# Altium schematic sheet Power.SchDoc
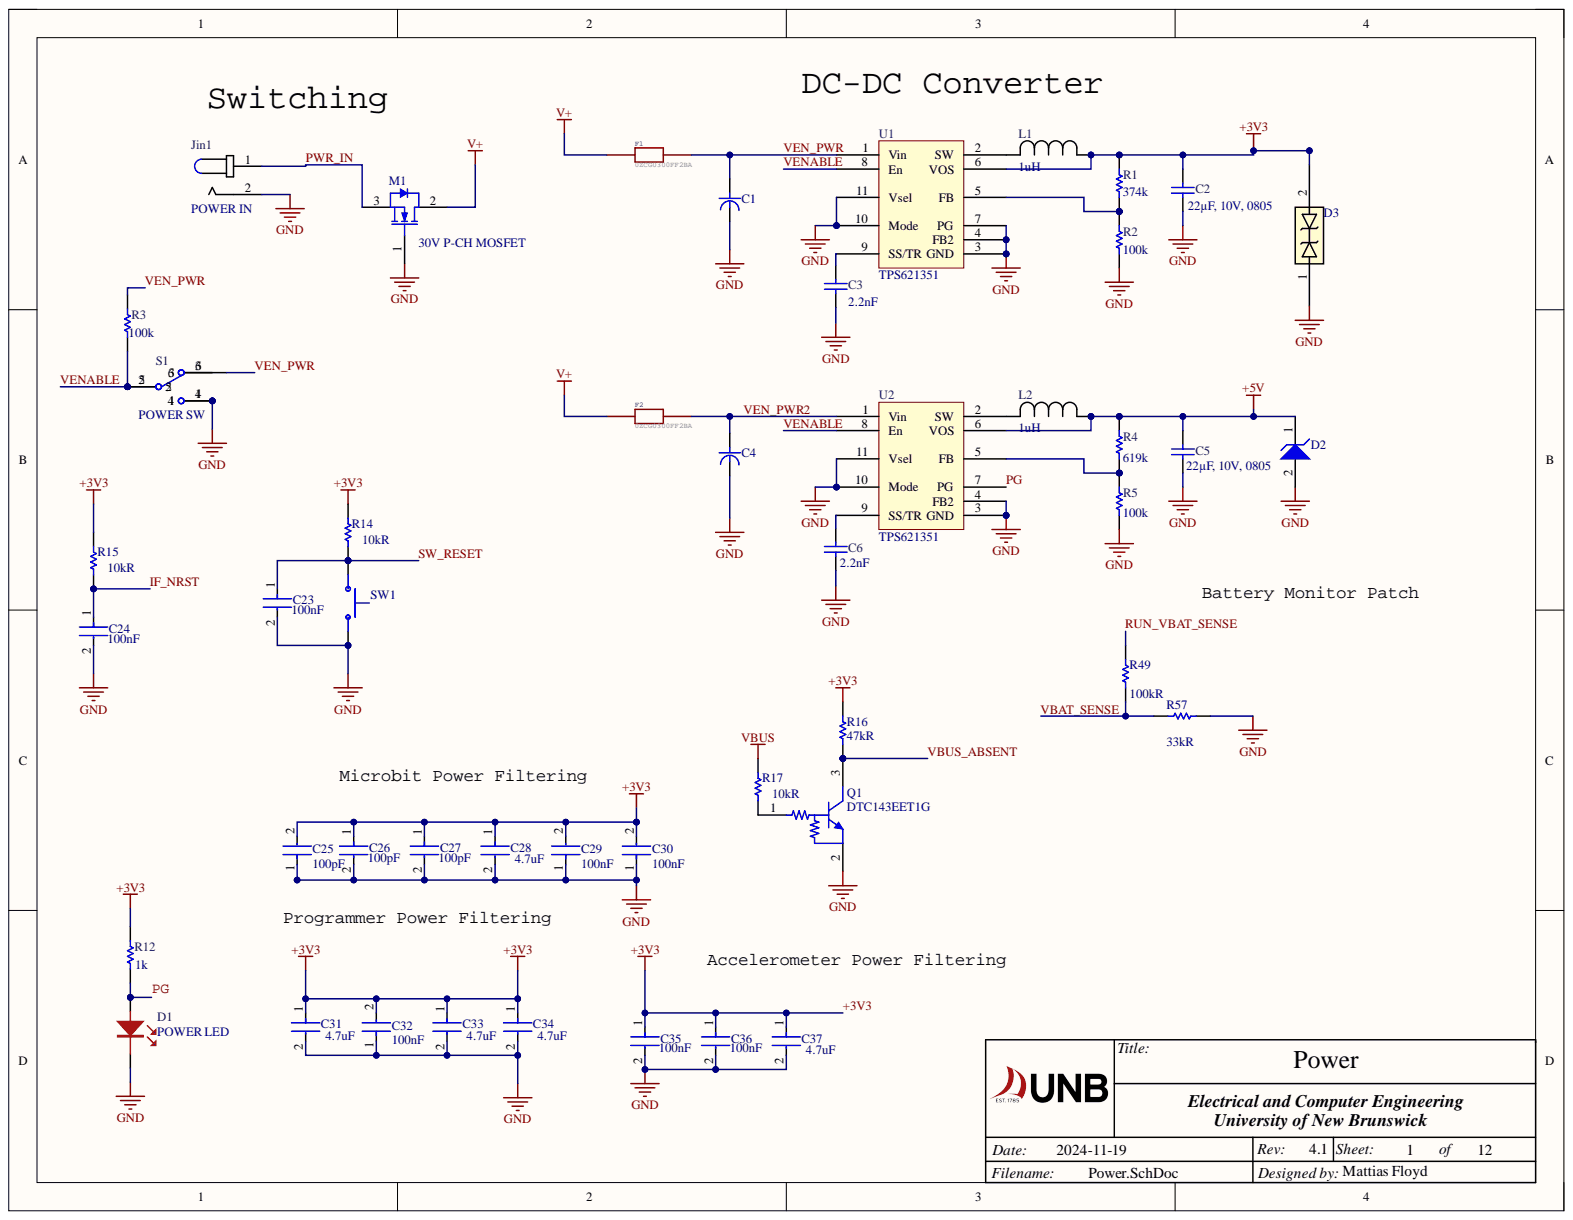
[diagram p0: Power.SchDoc]

## Parts
C1  CGA5L1X7R1V685K160AC  [CAPC3216X190N]  (value not drawn)
C2  22µF, 10V, 0805  [0805 Cap]
C3  2.2nF  [0603 Cap]
C4  CGA5L1X7R1V685K160AC  [CAPC3216X190N]  (value not drawn)
C5  22µF, 10V, 0805  [0805 Cap]
C6  2.2nF  [0603 Cap]
C23  Capacitance: 100nF  [0402 CAP]  (hidden comment: CAP GRM155R61H104KE14D)
C24  Capacitance: 100nF  [0402 CAP]  (hidden comment: CAP GRM155R61H104KE14D)
C25  Capacitance: 100pF  [0402 CAP]  (hidden comment: CAP CL05C101JB5NNNC)
C26  Capacitance: 100pF  [0402 CAP]  (hidden comment: CAP CL05C101JB5NNNC)
C27  Capacitance: 100pF  [0402 CAP]  (hidden comment: CAP CL05C101JB5NNNC)
C28  Capacitance: 4.7uF  [0402 CAP]  (hidden comment: CAP C1005X5R1A475K050BC)
C29  Capacitance: 100nF  [0402 CAP]  (hidden comment: CAP GRM155R61H104KE14D)
C30  Capacitance: 100nF  [0402 CAP]  (hidden comment: CAP GRM155R61H104KE14D)
C31  Capacitance: 4.7uF  [0402 CAP]  (hidden comment: CAP C1005X5R1A475K050BC)
C32  Capacitance: 100nF  [0402 CAP]  (hidden comment: CAP GRM155R61H104KE14D)
C33  Capacitance: 4.7uF  [0402 CAP]  (hidden comment: CAP C1005X5R1A475K050BC)
C34  Capacitance: 4.7uF  [0402 CAP]  (hidden comment: CAP C1005X5R1A475K050BC)
C35  Capacitance: 100nF  [0402 CAP]  (hidden comment: CAP GRM155R61H104KE14D)
C36  Capacitance: 100nF  [0402 CAP]  (hidden comment: CAP GRM155R61H104KE14D)
C37  Capacitance: 4.7uF  [0402 CAP]  (hidden comment: CAP C1005X5R1A475K050BC)
D1  POWER LED  [LED_0603_RED]
D2  MM3Z5V1ST1G  [ONSC-SOD-323-2-477-02_V]  (value not drawn)
D3  DIODE D5V0H1B2LP-7B  [X1-DFN1006-2_DIO-L]  (value not drawn)
F1  0ZCG0300FF2BA  [0ZCF]
F2  0ZCG0300FF2BA  [0ZCF]
Jin1  POWER IN  [CUI_PJ-063AH_PJ-063AH(Primary)]
L1  1uH  [ASPI-0530HI]
L2  1uH  [ASPI-0530HI]
M1  30V P-CH MOSFET  [INF-PG-SOT-323_L]
Q1  DTC143EET1G  [ONSC-SC-75-3-463-01_V]
R1  374k  [0603 Resistor]
R2  100k  [0603 Resistor]
R3  100k  [0603 Resistor]
R4  619k  [0603 Resistor]
R5  100k  [0603 Resistor]
R12  1k  [0603 Resistor]
R14  Resistance: 10kR  [0402 RES]  (hidden comment: RES MC00625W0402110K)
R15  Resistance: 10kR  [0402 RES]  (hidden comment: RES MC00625W0402110K)
R16  Resistance: 47kR  [0402 RES]  (hidden comment: RES RC0402JR-0747KL)
R17  Resistance: 10kR  [0402 RES]  (hidden comment: RES MC00625W0402110K)
R49  Resistance: 100kR  [0402 RES]  (hidden comment: RES MCWR04X1003FTL)
R57  Resistance: 33kR  [0402 RES]  (hidden comment: RES RC0402JR-0733KL)
S1  POWER SW  [EG1271A]
SW1  PTS636SK25FSMTRLFS  [PTS636 SK25F SMTR LFS]  (value not drawn)
U1  TPS621351  [VQFN]
U2  TPS621351  [VQFN]

## Nets
+5V: C5.2 D2.1 L2.1 R4.1 U2.6(VOS)
GND: C1.2 C2.1 C3.1 C4.2 C5.1 C6.1 C23.2 C24.2 C25.1 C26.2 C27.2 C28.2 C29.1 C30.1 C31.2 C32.1 C33.2 C34.2 C35.2 C36.2 C37.2 D1.1 D2.2 D3.1 Jin1.2 M1.1 Q1.2 R2.2 R5.2 R57.2 S1.1 S1.4 SW1.2 U1.3(GND) U1.4(FB2) U1.7(PG) U1.10(Mode) U1.11(Vsel) U2.3(GND) U2.4(FB2) U2.10(Mode) U2.11(Vsel)
V+: F1.P$1 F2.P$1 M1.2
VBUS: R17.2
+3V3: C2.2 C25.2 C26.1 C27.1 C28.1 C29.2 C30.2 C31.1 C32.2 C33.1 C34.1 C35.1 C36.1 C37.1 D3.2 L1.1 R1.1 R12.2 R14.2 R15.2 R16.2 U1.6(VOS)
IF_NRST: C24.1 R15.1
PG: D1.2 R12.1 U2.7(PG)
PWR_IN: Jin1.1 M1.3
RUN_VBAT_SENSE: R49.2
SW_RESET: C23.1 R14.1 SW1.1
VBAT_SENSE: R49.1 R57.1
VBUS_ABSENT: Q1.3 R16.1
VEN_PWR: C1.1 F1.P$2 R3.1 S1.6 U1.1(Vin)
VEN_PWR2: C4.1 F2.P$2 U2.1(Vin)
VENABLE: R3.2 S1.2 S1.5 U1.8(En) U2.8(En)
NetC3_2: C3.2 U1.9(SS/TR)
NetC6_2: C6.2 U2.9(SS/TR)
NetL1_2: L1.2 U1.2(SW)
NetL2_2: L2.2 U2.2(SW)
NetQ1_1: Q1.1 R17.1
NetR1_2: R1.2 R2.1 U1.5(FB)
NetR4_2: R4.2 R5.1 U2.5(FB)

Not connected: S1 3

## Library data of the parts
C1 C4 = CGA5L1X7R1V685K160AC: Ceramic Capacitor, Multilayer, Ceramic, 35V, 10% +Tol, 10% -Tol, X7R, 15% TC, 6.8uF, Surface Mount, 1206; Manufacturer: TDK; Multilayer: Yes; Capacitance: 6.8uF; Capacitor Type: Ceramic; Category: Ceramic Capacitors; Component Kind: Standard; ComponentLink1Description: https://datasheet.ciiva.com:443/pdfs/VipMasterIC/IC/TDKC/TDKC-S-A0008953915/TDKC-S-A0008953915-1.pdf?src-supplier=IHS+Markit; ComponentLink1URL: https://datasheet.ciiva.com:443/pdfs/VipMasterIC/IC/TDKC/TDKC-S-A0008953915/TDKC-S-A0008953915-1.pdf?src-supplier=IHS+Markit; ComponentLink2Description: http://product.tdk.com/capacitor/mlcc/detailed_information.php?lang=en&ref=jp&part_no=CGA5L1X7R1V685K160AC&src-supplier=Mouser; ComponentLink2URL: http://product.tdk.com/capacitor/mlcc/detailed_information.php?lang=en&ref=jp&part_no=CGA5L1X7R1V685K160AC&src-supplier=Mouser; ComponentLink3Description: https://product.tdk.com/info/en/catalog/datasheets/mlcc_automotive_general_en.pdf?src-supplier=Digikey; ComponentLink3URL: https://product.tdk.com/info/en/catalog/datasheets/mlcc_automotive_general_en.pdf?src-supplier=Digikey; ComponentLink4Description: https://product.tdk.com/info/en/catalog/spec/mlccspec_automotive_general_midvoltage_en.pdf?src-supplier=Digikey; ComponentLink4URL: https://product.tdk.com/info/en/catalog/spec/mlccspec_automotive_general_midvoltage_en.pdf?src-supplier=Digikey; ComponentLink5Description: http://product.tdk.com/capacitor/mlcc/class/print_detail_pdf.php?lang=en&part_no=CGA5L1X7R1V685K160AC&src-supplier=Avnet; ComponentLink5URL: http://product.tdk.com/capacitor/mlcc/class/print_detail_pdf.php?lang=en&part_no=CGA5L1X7R1V685K160AC&src-supplier=Avnet; ComponentLink6Description: https://datasheet.octopart.com/CGA5L1X7R1V685K160AC-TDK-datasheet-165583812.pdf?src-supplier=TME; ComponentLink6URL: https://datasheet.octopart.com/CGA5L1X7R1V685K160AC-TDK-datasheet-165583812.pdf?src-supplier=TME; Dielectric Material: Ceramic; Height: 1.6mm; JESD-609 Code: e3; Length: 3.2mm; Manufacturer Part Number: CGA5L1X7R1V685K160AC; Max Operating Temperature: 125°C; Min Operating Temperature: -55°C; Negative Tolerance: 10%; Number of Pins: 2; Package Style: SMTMeter; Packaging: Tape and Reel; Positive Tolerance: 10%; Pricing 1: 1=0.76, 10=0.531, 50=0.447, 100=0.364, 500=0.30334, 1000=0.25544 (USD); Rated DC Voltage (URdc): 35V; Reach SVHC Compliant: Yes; RoHS: Yes; RoHS Compliant: Yes; Size Code: 1206; Stock 1: 11896; Supplier 1: Digikey; Supplier Part Number 1: 445-181026-1-ND; Temperature Characteristics Code: X7R; Terminal Finish: Nickel; Width: 1.6mm; Library Name: bBoard for UNB Rev 4.SCHLIB
C2 C5 = Cap Semi: CAPACITOR, MLCC, X5R, 22UF, 10V, 0805; Product Range:C Series; Capacitance:22 F; Capacitance Tolerance: 20%; Dielectric Characteristic:X5R; Voltage Rating:10V; Ceramic Capacitor Case:0805 [2012 Metric]; Operating Temperature ;RoHS Compliant: Yes; Manufacturer: TDK; Manufacturer Part Number: C2012X5R1A226M085AC; Capacitance: 22uF; Case Code (Imperial): 0805; Case Code (Metric): 2012; Case/Package: 0805; Category: Ceramic Capacitors; Component Kind: Standard; ComponentLink1Description: http://www.avx.com/docs/Catalogs/cx7r.pdf; ComponentLink1URL: http://www.avx.com/docs/Catalogs/cx7r.pdf; ComponentLink2Description: https://datasheet.ciiva.com/24216/c2012x5r1a226m085ac-264883-24216482.pdf?src-supplier=Mouser; ComponentLink2URL: https://datasheet.ciiva.com/24216/c2012x5r1a226m085ac-264883-24216482.pdf?src-supplier=Mouser; ComponentLink3Description: https://datasheet.ciiva.com/26834/getdatasheetpartid-711053-26834411.pdf?src-supplier=Verical; ComponentLink3URL: https://datasheet.ciiva.com/26834/getdatasheetpartid-711053-26834411.pdf?src-supplier=Verical; ComponentLink4Description: https://datasheet.ciiva.com/6287/tdkmlcccaprange-6287141.pdf?src-supplier=Digi-Key; ComponentLink4URL: https://datasheet.ciiva.com/6287/tdkmlcccaprange-6287141.pdf?src-supplier=Digi-Key; ComponentLink5Description: https://datasheet.ciiva.com/16471/e412-c-16471846.pdf?src-supplier=Future+Electronics; ComponentLink5URL: https://datasheet.ciiva.com/16471/e412-c-16471846.pdf?src-supplier=Future+Electronics; ComponentLink6Description: https://datasheet.ciiva.com/6287/tdkmlcccaprange-6287144.pdf?src-supplier=Digi-Key; ComponentLink6URL: https://datasheet.ciiva.com/6287/tdkmlcccaprange-6287144.pdf?src-supplier=Digi-Key; ComponentLink7Description: https://datasheet.ciiva.com/6287/tdkmlcccaprange-6287147.pdf?src-supplier=Digi-Key; ComponentLink7URL: https://datasheet.ciiva.com/6287/tdkmlcccaprange-6287147.pdf?src-supplier=Digi-Key; Composition: Ceramic; Depth: 1.25mm; Dielectric: X5R; Dielectric Material: Ceramic; Height: 0.85mm; Lead Free: Lead Free; Length: 2mm; Library Name: CAN_Interface_Library.SCHLIB; Max Operating Temperature: 85°C; Min Operating Temperature: -55°C; Mount: Surface Mount; Package Quantity: 1; Packaging: Tape and Reel; Radiation Hardening: No; REACH SVHC: No SVHC; RoHS: Yes; Signal Integrity: Cap Semi; Simulation: CAP; Stock 1: 64294; Supplier 1: Digi-Key; Supplier Part Number 1: 445-7663-1-ND; Termination: SMD/SMT; Thickness: 0.037inch; Tolerance: 20%; Value: 22µF, 10V, 0805; Voltage Rating: 10V; Voltage Rating (DC): 10V; Weight: 0.000194oz; Width: 1.25mm
C3 C6 = Cap Semi: Cap Ceramic 0.0022uF 50VDC X7R 10% SMD 0603 Paper T/R; Manufacturer: Samsung; Manufacturer Part Number: CL10B222KB8NNNC; Voltage: 25V; Voltage Rating: 50V; Capacitance: 2.2nF; Case Code (Imperial): 0603; Case Code (Metric): 1608; Case/Package: 0603; Category: Ceramic Capacitors; Component Kind: Standard; ComponentLink1Description: http://www.avx.com/docs/Catalogs/cx7r.pdf; ComponentLink1URL: http://www.avx.com/docs/Catalogs/cx7r.pdf; ComponentLink2Description: https://datasheet.ciiva.com/15195/getdatasheetpartid-354051-15195736.pdf?src-supplier=Verical; ComponentLink2URL: https://datasheet.ciiva.com/15195/getdatasheetpartid-354051-15195736.pdf?src-supplier=Verical; ComponentLink3Description: https://datasheet.ciiva.com/29198/458008-da-01-en-vs-kondensator-0603-29198600.pdf?src-supplier=Conrad; ComponentLink3URL: https://datasheet.ciiva.com/29198/458008-da-01-en-vs-kondensator-0603-29198600.pdf?src-supplier=Conrad; ComponentLink4Description: https://datasheet.ciiva.com/26310/mlcc-catalogdownload2013-eng-26310312.pdf?src-supplier=Distrelec; ComponentLink4URL: https://datasheet.ciiva.com/26310/mlcc-catalogdownload2013-eng-26310312.pdf?src-supplier=Distrelec; Contact Plating: Tin; Depth: 0.8mm; Dielectric: X7R; Height: 0.8mm; Lead Free: Lead Free; Length: 1.6mm; Library Name: CAN_Interface_Library.SCHLIB; Material: Ceramic; Max Operating Temperature: 125°C; Min Operating Temperature: -55°C; Mount: Surface Mount; Package Quantity: 1; Packaging: Tape and Reel; Radiation Hardening: No; RoHS: Yes; Signal Integrity: Cap Semi; Simulation: CAP; Stock 1: 366327; Supplier 1: Digi-Key; Supplier Part Number 1: 1276-1110-1-ND; Thickness: 0.035inch; Tolerance: 10%; Value: 2.2nF; Voltage Rating (DC): 50V; Width: 0.031inch
C23 C24 C29 C30 C32 C35 C36 = CAP GRM155R61H104KE14D: Ceramic Capacitor, Multilayer, Ceramic, 50V, 10% +Tol, 10% -Tol, X5R, 15% TC, 0.1uF, Surface Mount, 0402; Manufacturer: Murata; Multilayer: Yes; Capacitor Type: Ceramic; Category: Ceramic Capacitors; Component Kind: Standard; ComponentLink1Description: https://datasheet.ciiva.com:443/pdfs/VipMasterIC/IC/MURE/MURE-S-A0004362469/MURE-S-A0004364758-1.pdf?src-supplier=IHS+Markit; ComponentLink1URL: https://datasheet.ciiva.com:443/pdfs/VipMasterIC/IC/MURE/MURE-S-A0004362469/MURE-S-A0004364758-1.pdf?src-supplier=IHS+Markit; ComponentLink2Description: http://www.mouser.com/ds/2/281/c02e-2905.pdf?src-supplier=Mouser; ComponentLink2URL: http://www.mouser.com/ds/2/281/c02e-2905.pdf?src-supplier=Mouser; ComponentLink3Description: http://www.farnell.com/datasheets/2079150.pdf?src-supplier=Farnell; ComponentLink3URL: http://www.farnell.com/datasheets/2079150.pdf?src-supplier=Farnell; ComponentLink4Description: http://www.farnell.com/datasheets/2079150.pdf?src-supplier=Element14; ComponentLink4URL: http://www.farnell.com/datasheets/2079150.pdf?src-supplier=Element14; ComponentLink5Description: https://datasheet.octopart.com/GRM155R61H104KE14D-Murata-datasheet-66077066.pdf?src-supplier=Element14; ComponentLink5URL: https://datasheet.octopart.com/GRM155R61H104KE14D-Murata-datasheet-66077066.pdf?src-supplier=Element14; ComponentLink6Description: http://search.murata.co.jp/Ceramy/image/img/A01X/G101/ENG/GRM155R61H104KE14-01.pdf?src-supplier=Digikey; ComponentLink6URL: http://search.murata.co.jp/Ceramy/image/img/A01X/G101/ENG/GRM155R61H104KE14-01.pdf?src-supplier=Digikey; ComponentLink7Description: https://datasheet.octopart.com/GRM155R61H104KE14D-Murata-datasheet-22122223.pdf?src-supplier=Future+Electronics; ComponentLink7URL: https://datasheet.octopart.com/GRM155R61H104KE14D-Murata-datasheet-22122223.pdf?src-supplier=Future+Electronics; ComponentLink8Description: https://datasheet.octopart.com/GRM155R61H104KE14D-Murata-datasheet-149523029.pdf?src-supplier=Newark; ComponentLink8URL: https://datasheet.octopart.com/GRM155R61H104KE14D-Murata-datasheet-149523029.pdf?src-supplier=Newark; Dielectric Material: Ceramic; Height: 0.5mm; JESD-609 Code: e3; Length: 1mm; Manufacturer Part Number: GRM155R61H104KE14D; Max Operating Temperature: 85°C; Min Operating Temperature: -55°C; Mount: Surface Mount; Negative Tolerance: 10%; Number of Pins: 2; Package Shape: Rectangular; Package Style: SMTMeter; Packaging: Tape and Reel; Positive Tolerance: 10%; Pricing 1: 1=0.1, 10=0.031, 50=0.018, 100=0.0139, 500=0.01046, 1000=0.00821, 2500=0.00747, 5000=0.00687 (USD); Rated DC Voltage (URdc): 50V; Reach SVHC Compliant: Yes; RoHS: Yes; RoHS Compliant: Yes; Size Code: 0402; Stock 1: 1615754; Supplier 1: Digikey; Temperature Characteristics Code: X5R; Terminal Finish: Nickel; Termination: Wraparound; Width: 0.5mm; Library Name: bBoard for UNB Rev 3.SCHLIB
C25-C27 = CAP CL05C101JB5NNNC: Ceramic Capacitor, Multilayer, Ceramic, 50V, 5% +Tol, 5% -Tol, C0G, -/+30ppm/Cel TC, 0.0001uF, Surface Mount, 0402; Manufacturer: Samsung; Multilayer: Yes; Capacitor Type: Ceramic; Category: Ceramic Capacitors; Component Kind: Standard; ComponentLink1Description: https://datasheet.ciiva.com:443/pdfs/VipMasterIC/IC/SEMS/SEMS-S-A0008529205/SEMS-S-A0008529205-1.pdf?src-supplier=IHS+Markit; ComponentLink1URL: https://datasheet.ciiva.com:443/pdfs/VipMasterIC/IC/SEMS/SEMS-S-A0008529205/SEMS-S-A0008529205-1.pdf?src-supplier=IHS+Markit; ComponentLink2Description: https://datasheet.ciiva.com/15195/getdatasheetpartid-357528-15195665.pdf?src-supplier=Verical; ComponentLink2URL: https://datasheet.ciiva.com/15195/getdatasheetpartid-357528-15195665.pdf?src-supplier=Verical; ComponentLink3Description: https://datasheet.ciiva.com/29198/458492-da-01-en-vs-kondensator-0402-29198529.pdf?src-supplier=Conrad; ComponentLink3URL: https://datasheet.ciiva.com/29198/458492-da-01-en-vs-kondensator-0402-29198529.pdf?src-supplier=Conrad; ComponentLink4Description: https://datasheet.ciiva.com/16379/cl05b104kq5nnnc-16379226.pdf?src-supplier=Future+Electronics; ComponentLink4URL: https://datasheet.ciiva.com/16379/cl05b104kq5nnnc-16379226.pdf?src-supplier=Future+Electronics; Dielectric Material: Ceramic; Height: 0.55mm; JESD-609 Code: e3; Length: 1mm; Manufacturer Part Number: CL05C101JB5NNNC; Max Operating Temperature: 125°C; Min Operating Temperature: -55°C; Mount: Surface Mount; Negative Tolerance: 5%; Number of Pins: 2; Package Shape: Rectangular; Package Style: SMTMeter; Packaging: Tape and Reel; Positive Tolerance: 5%; Pricing 1: 1=0.1, 10=0.017, 50=0.01, 100=0.0077, 500=0.00584, 1000=0.00458, 2500=0.00417, 5000=0.00383 (USD); Rated DC Voltage (URdc): 50V; Reach SVHC Compliant: Yes; RoHS: Yes; RoHS Compliant: Yes; Size Code: 0402; Stock 1: 4909001; Supplier 1: Digikey; Supplier Part Number 1: 1276-1025-6-ND; Temperature Characteristics Code: C0G; Terminal Finish: Nickel; Termination: Wraparound; Width: 0.5mm; Library Name: bBoard for UNB Rev 3.SCHLIB
C28 C31 C33 C34 C37 = CAP C1005X5R1A475K050BC: Ceramic Capacitor, Multilayer, Ceramic, 10V, 10% +Tol, 10% -Tol, X5R, 15% TC, 4.7uF, Surface Mount, 0402; Manufacturer: TDK; Multilayer: Yes; Capacitor Type: Ceramic; Category: Ceramic Capacitors; Component Kind: Standard; ComponentLink1Description: https://datasheet.ciiva.com:443/pdfs/VipMasterIC/IC/TDKC/TDKC-S-A0008796792/TDKC-S-A0008796792-1.pdf?src-supplier=IHS+Markit; ComponentLink1URL: https://datasheet.ciiva.com:443/pdfs/VipMasterIC/IC/TDKC/TDKC-S-A0008796792/TDKC-S-A0008796792-1.pdf?src-supplier=IHS+Markit; ComponentLink2Description: https://datasheet.ciiva.com/14895/tdkmlcccaprange-14895670.pdf?src-supplier=Digikey; ComponentLink2URL: https://datasheet.ciiva.com/14895/tdkmlcccaprange-14895670.pdf?src-supplier=Digikey; ComponentLink3Description: https://datasheet.ciiva.com/14895/tdkmlcccaprange-14895671.pdf?src-supplier=Digikey; ComponentLink3URL: https://datasheet.ciiva.com/14895/tdkmlcccaprange-14895671.pdf?src-supplier=Digikey; ComponentLink4Description: https://datasheet.ciiva.com/14895/tdkmlcccaprange-14895672.pdf?src-supplier=Digikey; ComponentLink4URL: https://datasheet.ciiva.com/14895/tdkmlcccaprange-14895672.pdf?src-supplier=Digikey; Dielectric Material: Ceramic; Height: 0.5mm; JESD-609 Code: e3; Length: 1mm; Manufacturer Part Number: C1005X5R1A475K050BC; Max Operating Temperature: 85°C; Min Operating Temperature: -55°C; Mount: Surface Mount; Negative Tolerance: 10%; Number of Pins: 2; Package Shape: Rectangular; Package Style: SMTMeter; Packaging: Tape and Reel; Positive Tolerance: 10%; Pricing 1: 1=0.28, 10=0.183, 50=0.1282, 100=0.1035, 500=0.07688, 1000=0.06727, 2500=0.06406, 5000=0.05926 (USD); Rated DC Voltage (URdc): 10V; Reach SVHC Compliant: Yes; RoHS: Yes; RoHS Compliant: Yes; Size Code: 0402; Stock 1: 1776806; Supplier 1: Digikey; Supplier Part Number 1: 445-13820-6-ND; Temperature Characteristics Code: X5R; Terminal Finish: Nickel; Termination: Wraparound; Width: 0.5mm; Library Name: bBoard for UNB Rev 4.SCHLIB
D1 = LED_0603_RED: LED, Red, 630 nm, 1.9 V, 30 mA, 60 mcd; Manufacturer: Wurth Electronics; Manufacturer Part Number: 150060SS75000; Dominant Wavelength: 630nm; Forward Current: 30mA; Forward Voltage: 1.9V; Lens Style: Clear,Rectangular; Luminous Intensity: 60mcd; Test Current: 20mA; Viewing Angle: 140°; Case Code (Imperial): 0603; Case Code (Metric): 1608; Case/Package: 0603; Category: LEDs; Color: Red; Component Kind: Standard; ComponentLink1Description: https://datasheet.ciiva.com/23843/150060ss75000-23843248.pdf?src-supplier=Newark; ComponentLink1URL: https://datasheet.ciiva.com/23843/150060ss75000-23843248.pdf?src-supplier=Newark; ComponentLink2Description: https://datasheet.ciiva.com/29279/759429-da-01-en-chipled-0603-w-kl-s-rot-150060ss75000-29279208.pdf?src-supplier=Conrad; ComponentLink2URL: https://datasheet.ciiva.com/29279/759429-da-01-en-chipled-0603-w-kl-s-rot-150060ss75000-29279208.pdf?src-supplier=Conrad; ComponentLink3Description: https://datasheet.ciiva.com/48430/150060ss75000-eng-tds-48430042.pdf?src-supplier=Distrelec; ComponentLink3URL: https://datasheet.ciiva.com/48430/150060ss75000-eng-tds-48430042.pdf?src-supplier=Distrelec; Height: 0.7mm; Length: 1.6mm; Max Operating Temperature: 85°C; Min Operating Temperature: -40°C; Mount: Surface Mount; Package Quantity: 4000; Packaging: Tape and Reel; REACH SVHC: Unknown; RoHS: Yes; Stock 1: 15937; Supplier 1: Digi-Key; Supplier Part Number 1: 732-4979-1-ND; Wavelength: 630nm; Width: 0.8mm; Library Name: bBoard for UNB Rev 4.SCHLIB
D2 = CMP-1060-00694-1: Zener Voltage Regulator, 300 mW, Tight Tolerance Portfolio, 2-Pin SOD-323, Pb-Free, Tape and Reel; Manufacturer: ON Semiconductor; Element Configuration: Single; ESD Protection: Yes; Forward Voltage: 900mV; Impedance: 60R; Material: Plastic; Max Power Dissipation: 200mW; Max Reverse Leakage Current: 2uA; Test Current: 5mA; Tolerance: 2%; Voltage Rating (DC): 5.1V; Zener Current: 10mA; Zener Voltage: 5.09V; Case/Package: SOD-323-2; Category: Zener Diodes; Component Kind: Standard; ComponentLink1Description: Manufacturer URL; ComponentLink1URL: http://www.onsemi.com/; ComponentLink2Description: Datasheet; ComponentLink2URL: http://www.onsemi.cn/pub_link/Collateral/MM3Z2V4ST1-D.PDF; ComponentLink3Description: Package Specification; ComponentLink3URL: http://www.onsemi.com/pub_link/Collateral/477-02.PDF.1; ComponentLink4Description: https://datasheet.ciiva.com/10630/mm3z2v4st1-d-10630224.pdf?src-supplier=Avnet; ComponentLink4URL: https://datasheet.ciiva.com/10630/mm3z2v4st1-d-10630224.pdf?src-supplier=Avnet; ComponentLink5Description: https://datasheet.ciiva.com/894/mm3z2v4st1-d-894646.pdf?src-supplier=Digi-Key; ComponentLink5URL: https://datasheet.ciiva.com/894/mm3z2v4st1-d-894646.pdf?src-supplier=Digi-Key; ComponentLink6Description: https://datasheet.ciiva.com/25928/mm3z2v4st1-d-25928233.pdf?src-supplier=Avnet+Europe; ComponentLink6URL: https://datasheet.ciiva.com/25928/mm3z2v4st1-d-25928233.pdf?src-supplier=Avnet+Europe; ComponentLink7Description: https://datasheet.ciiva.com/22626/1878251-22626909.pdf?src-supplier=Element14; ComponentLink7URL: https://datasheet.ciiva.com/22626/1878251-22626909.pdf?src-supplier=Element14; ComponentLink8Description: https://datasheet.ciiva.com/894/mm3z2v4st1-d-894647.pdf?src-supplier=Digi-Key; ComponentLink8URL: https://datasheet.ciiva.com/894/mm3z2v4st1-d-894647.pdf?src-supplier=Digi-Key; ComponentLink9Description: https://datasheet.ciiva.com/894/mm3z2v4st1-d-894648.pdf?src-supplier=Digi-Key; ComponentLink9URL: https://datasheet.ciiva.com/894/mm3z2v4st1-d-894648.pdf?src-supplier=Digi-Key; ComponentLink10Description: https://datasheet.ciiva.com/16397/mm3z2v4st1-d-16397690.pdf?src-supplier=Future+Electronics; ComponentLink10URL: https://datasheet.ciiva.com/16397/mm3z2v4st1-d-16397690.pdf?src-supplier=Future+Electronics; ComponentLink11Description: https://datasheet.ciiva.com/22626/1878251-22626906.pdf?src-supplier=Element14; ComponentLink11URL: https://datasheet.ciiva.com/22626/1878251-22626906.pdf?src-supplier=Element14; ComponentLink12Description: https://datasheet.ciiva.com/16473/mm3z2v4st1-d-16473517.pdf?src-supplier=Avnet+Asia; ComponentLink12URL: https://datasheet.ciiva.com/16473/mm3z2v4st1-d-16473517.pdf?src-supplier=Avnet+Asia; Contact Plating: Tin,Matte; Height: 1mm; Lead Free: Lead Free; Length: 1.8mm; Library Name: Altium Content Vault; Manufacturer Part Number: MM3Z5V1ST1G; Max Operating Temperature: 150°C; Min Operating Temperature: -65°C; Number of Pins: 2; Package Quantity: 3000; Packaging: Tape and Reel; Peak Reverse Current: 2uA; Power Dissipation: 200mW; Power Rating: 200mW; Radiation Hardening: No; REACH SVHC: No SVHC; RoHS: Yes; Stock 1: 204185; Supplier 1: Digi-Key; Supplier Part Number 1: MM3Z5V1ST1GOSCT-ND; Voltage Tolerance: 2%; Width: 1.35mm; Working Voltage: 5.1V
D3 = DIODE D5V0H1B2LP-7B: Trans Voltage Suppressor Diode, 375W, 5.5V V(RWM), Bidirectional, 1 Element, Silicon; Manufacturer: Diodes; Max Breakdown Voltage: 9V; Max Power Dissipation: 250mW; Min Breakdown Voltage: 6V; Number of Elements: 1; Package Body Material: Plastic; Reference Standard: IEC-61000-4-5; Category: TVS Diodes; Component Kind: Standard; ComponentLink1Description: https://datasheet.ciiva.com:443/pdfs/VipMasterIC/IC/DIOD/DIOD-S-A0009689747/DIOD-S-A0009691837-1.pdf?src-supplier=IHS+Markit; ComponentLink1URL: https://datasheet.ciiva.com:443/pdfs/VipMasterIC/IC/DIOD/DIOD-S-A0009689747/DIOD-S-A0009691837-1.pdf?src-supplier=IHS+Markit; ComponentLink2Description: https://datasheet.octopart.com/D5V0H1B2LP-7B-Diodes-Inc.-datasheet-144967973.pdf?src-supplier=Farnell; ComponentLink2URL: https://datasheet.octopart.com/D5V0H1B2LP-7B-Diodes-Inc.-datasheet-144967973.pdf?src-supplier=Farnell; ComponentLink3Description: https://www.diodes.com/assets/Datasheets/D5V0H1B2LP.pdf?src-supplier=Digikey; ComponentLink3URL: https://www.diodes.com/assets/Datasheets/D5V0H1B2LP.pdf?src-supplier=Digikey; ComponentLink4Description: https://datasheet.octopart.com/D5V0H1B2LP-7B-Diodes-Inc.-datasheet-144967973.pdf?src-supplier=Newark; ComponentLink4URL: https://datasheet.octopart.com/D5V0H1B2LP-7B-Diodes-Inc.-datasheet-144967973.pdf?src-supplier=Newark; Diode Element Material: SILICON; Diode Type: TRANS VOLTAGE SUPPRESSOR; Manufacturer Part Number: D5V0H1B2LP-7B; Max Operating Temperature: 150°C; Min Operating Temperature: -65°C; Non-rep Peak Rev Power Dis-Max: 375W; Number of Pins: 2; Package Shape: Rectangular; Package Style: CHIP CARRIERMeter; Polarity: Bidirectional; Pricing 1: 1=0.24, 10=0.164, 100=0.08, 500=0.06672, 1000=0.04636, 2000=0.04018, 5000=0.03727 (USD); Reach SVHC Compliant: Yes; Rep Pk Reverse Voltage-Max: 5.5V; RoHS: Yes; RoHS Compliant: Yes; Stock 1: 206000; Supplier 1: Digikey; Supplier Part Number 1: D5V0H1B2LP-7BDICT-ND; Surface Mount: Yes; Technology: AVALANCHE; Terminal Form: No Lead; Terminal Position: BOTTOM; Library Name: bBoard for UNB Rev 4.SCHLIB
F1 F2 = 0ZCG0300FF2BA: PTC RESET FUSE 24V 2A 2920; Manufacturer: Bel; Manufacturer Part Number: 0ZCF0200AF2C; Max Current Rating: 40A; Time to Trip: 5s; Trip Current: 4A; Case Code (Imperial): 2920; Case Code (Metric): 7351; Category: PTC Resettable Fuses; Component Kind: Standard; ComponentLink1Description: https://datasheet.ciiva.com/18550/0zcf-18550655.pdf?src-supplier=Digi-Key; ComponentLink1URL: https://datasheet.ciiva.com/18550/0zcf-18550655.pdf?src-supplier=Digi-Key; Hold Current: 2A; Lead Free: Lead Free; Package Quantity: 1; Packaging: Cut Tape; RoHS: Yes; Stock 1: 32175; Supplier 1: Digi-Key; Supplier Part Number 1: 507-1749-1-ND; Library Name: BrilliantLabsEagleLibrary.IntLib
Jin1 = PJ-063AH: CONN PWR JACK 2X5.5MM SOLDER; Manufacturer: CUI; Current Rating: 8A; Gender: Male; Insulation Resistance: 100MR; Number of Conductors: 2; Shielding: Shielded; Voltage Rating: 24V; Category: Connectors; Component Kind: Standard; ComponentLink1Description: http://www.cui.com/Product/Resource/FuturePDF/PJ-063AH.pdf?src-supplier=Future+Electronics; ComponentLink1URL: http://www.cui.com/Product/Resource/FuturePDF/PJ-063AH.pdf?src-supplier=Future+Electronics; ComponentLink2Description: https://www.cui.com/product/resource/digikeypdf/pj-063ah.pdf?src-supplier=Digi-Key; ComponentLink2URL: https://www.cui.com/product/resource/digikeypdf/pj-063ah.pdf?src-supplier=Digi-Key; ComponentLink3Description: https://www.cui.com/product/resource/digikeypdf/pj-063ah.pdf?src-supplier=Verical; ComponentLink3URL: https://www.cui.com/product/resource/digikeypdf/pj-063ah.pdf?src-supplier=Verical; ComponentLink4Description: https://www.cui.com/product/resource/digikeypdf/pj-063ah.pdf?src-supplier=Mouser; ComponentLink4URL: https://www.cui.com/product/resource/digikeypdf/pj-063ah.pdf?src-supplier=Mouser; ComponentLink5Description: https://www.cui.com/product/resource/digikeypdf/pj-063ah.pdf?src-supplier=Onlinecomponents.com; ComponentLink5URL: https://www.cui.com/product/resource/digikeypdf/pj-063ah.pdf?src-supplier=Onlinecomponents.com; ComponentLink6Description: https://www.cui.com/product/resource/digikeypdf/pj-063ah.pdf?src-supplier=Arrow+Electronics; ComponentLink6URL: https://www.cui.com/product/resource/digikeypdf/pj-063ah.pdf?src-supplier=Arrow+Electronics; ComponentLink7Description: https://www.cui.com/product/resource/digikeypdf/pj-063ah.pdf?src-supplier=NAC+Semi; ComponentLink7URL: https://www.cui.com/product/resource/digikeypdf/pj-063ah.pdf?src-supplier=NAC+Semi; ComponentLink8Description: https://www.cui.com/product/resource/digikeypdf/pj-063ah.pdf?src-supplier=Quest; ComponentLink8URL: https://www.cui.com/product/resource/digikeypdf/pj-063ah.pdf?src-supplier=Quest; Connector Type: Jack; Contact Gender: Male; Contact Material: Copper; Contact Plating: Nickel; Flammability Rating: UL94 V-0; Housing Color: Black; Housing Material: Thermoplastic; Lead Free: Lead Free; Manufacturer Part Number: PJ-063AH; Max Operating Temperature: 85°C; Min Operating Temperature: -25°C; Mount: Through Hole; Orientation: Horizontal; Package Quantity: 500; RoHS: Yes; Stock 1: 7747; Supplier 1: Digi-Key; Supplier Part Number 1: CP-063AH-ND; Termination: Through Hole; Library Name: BrilliantLabs_SchLib.SchLib
L1 L2 = Inductor: Fixed Inductors 1uH 20% -55C +125C; Manufacturer: Abracon; Manufacturer Part Number: ASPI-0530HI-1R0M-T2; Core Material: Carbonyl Powder; Current Rating: 7A; DC Resistance (DCR): 14mR; Max DC Current: 11A; Shielding: Unshielded; Test Frequency: 100kHz; Case/Package: Nonstandard; Category: Fixed Inductors; Component Kind: Standard; ComponentLink1Description: https://datasheet.ciiva.com/6691/aspi-0530hi-6691054.pdf?src-supplier=Digi-Key; ComponentLink1URL: https://datasheet.ciiva.com/6691/aspi-0530hi-6691054.pdf?src-supplier=Digi-Key; ComponentLink2Description: https://datasheet.ciiva.com/6691/aspi-0530hi-6691055.pdf?src-supplier=Digi-Key; ComponentLink2URL: https://datasheet.ciiva.com/6691/aspi-0530hi-6691055.pdf?src-supplier=Digi-Key; Composition: Wirewound; Height: 0.118inch; Height - Seated (Max): 0.118inch; Inductance: 1uH; Length: 0.22inch; Max Operating Temperature: 125°C; Min Operating Temperature: -55°C; Mount: Surface Mount; Package Quantity: 2000; Packaging: Tape and Reel; RoHS: Yes; Saturation Current: 11A; Stock 1: 21020; Supplier 1: Digi-Key; Supplier Part Number 1: 535-13037-1-ND; Termination: SMD/SMT; Tolerance: 20%; Value: 1uH; Width: 0.205inch; Library Name: bBoard for UNB Rev 4.SCHLIB
M1 = SI1317DL-T1-GE3: Small Signal Field-Effect Transistor, 1.4A I(D), 20V, 1-Element, P-Channel, Silicon, Metal-oxide Semiconductor FET; Manufacturer: Vishay Siliconix; Drain-source On Resistance-Max: 150mR; Number of Elements: 1; Operating Mode: ENHANCEMENT MODE; Package Body Material: Plastic; Power Dissipation-Max (Abs): 500mW; Transistor Application: SWITCHING; Category: MOSFETs; Component Kind: Standard; ComponentLink1Description: https://datasheet.ciiva.com:443/pdfs/VipMasterIC/IC/VISH/VISH-S-A0001109972/VISH-S-A0001109972-1.pdf?src-supplier=IHS+Markit; ComponentLink1URL: https://datasheet.ciiva.com:443/pdfs/VipMasterIC/IC/VISH/VISH-S-A0001109972/VISH-S-A0001109972-1.pdf?src-supplier=IHS+Markit; ComponentLink2Description: https://datasheet.ciiva.com/29746/si1317dl-29746785.pdf?src-supplier=Digikey; ComponentLink2URL: https://datasheet.ciiva.com/29746/si1317dl-29746785.pdf?src-supplier=Digikey; ComponentLink3Description: https://datasheet.ciiva.com/11158/si1317dl-11158622.pdf?src-supplier=Avnet; ComponentLink3URL: https://datasheet.ciiva.com/11158/si1317dl-11158622.pdf?src-supplier=Avnet; Drain Current-Max (Abs) (ID): 1.4A; Drain Current-Max (ID): 1.4A; DS Breakdown Voltage-Min: 20V; FET Technology: METAL-OXIDE SEMICONDUCTOR; JESD-30 Code: R-PDSO-G3; JESD-609 Code: e3; Manufacturer Part Number: SI1317DL-T1-GE3; Max Operating Temperature: 150°C; Moisture Sensitivity Level: 1; Number of Pins: 3; Package Shape: Rectangular; Package Style: SMALL OUTLINEMeter; Polarity/Channel Type: P-CHANNEL; Pricing 1: 1=0.44, 10=0.341, 100=0.2045, 500=0.18938, 1000=0.12878 (USD); Reach SVHC Compliant: Yes; RoHS: Yes; RoHS Compliant: Yes; Stock 1: 7904; Supplier 1: Digikey; Supplier Part Number 1: SI1317DL-T1-GE3CT-ND; Surface Mount: Yes; Terminal Finish: Tin; Terminal Form: Gull Wing; Terminal Position: DUAL; Transistor Element Material: SILICON; Library Name: bBoard for UNB Rev 4.SCHLIB
Q1 = DTC143EET1G: Small Signal Bipolar Transistor, 0.1A I(C), 50V V(BR)CEO, 1-Element, NPN, Silicon; Manufacturer: ON Semiconductor; DC Current Gain-Min (hFE): 15; Max Collector Current: 100mA; Number of Elements: 1; Package Body Material: Plastic; Power Dissipation-Max (Abs): 300mW; Qualification Status: Not Qualified; Time @ Peak Reflow Temperature-Max (s): 40s; Transistor Application: SWITCHING; Category: BJTs; Collector-emitter Voltage-Max: 50V; Component Kind: Standard; ComponentLink1Description: https://datasheet.ciiva.com:443/pdfs/VipMasterIC/IC/ONSM/ONSM-S-A0002809175/ONSM-S-A0002809175-1.pdf?src-supplier=IHS+Markit; ComponentLink1URL: https://datasheet.ciiva.com:443/pdfs/VipMasterIC/IC/ONSM/ONSM-S-A0002809175/ONSM-S-A0002809175-1.pdf?src-supplier=IHS+Markit; ComponentLink2Description: https://datasheet.ciiva.com/15139/getdatasheetpartid-38523-15139560.pdf?src-supplier=Verical; ComponentLink2URL: https://datasheet.ciiva.com/15139/getdatasheetpartid-38523-15139560.pdf?src-supplier=Verical; ComponentLink3Description: https://datasheet.ciiva.com/990/dtc143e-d-990648.pdf?src-supplier=Digikey; ComponentLink3URL: https://datasheet.ciiva.com/990/dtc143e-d-990648.pdf?src-supplier=Digikey; ComponentLink4Description: https://datasheet.ciiva.com/8120/dtc124e-d-8120717.pdf?src-supplier=Avnet; ComponentLink4URL: https://datasheet.ciiva.com/8120/dtc124e-d-8120717.pdf?src-supplier=Avnet; JESD-30 Code: R-PDSO-G3; JESD-609 Code: e3; Manufacturer Part Number: DTC143EET1G; Max Operating Temperature: 150°C; Moisture Sensitivity Level: 1; Number of Pins: 3; Package Shape: Rectangular; Package Style: SMALL OUTLINEMeter; Peak Reflow Temperature (Cel): 260°C; Polarity/Channel Type: NPN; Pricing 1: 1=0.2, 10=0.14, 100=0.0684, 500=0.05708, 1000=0.03966 (USD); Reach SVHC Compliant: Yes; RoHS: Yes; RoHS Compliant: Yes; Stock 1: 287169; Supplier 1: Digikey; Supplier Part Number 1: DTC143EET1GOSDKR-ND; Surface Mount: Yes; Terminal Finish: Tin; Terminal Form: Gull Wing; Terminal Position: DUAL; Transistor Element Material: SILICON; Library Name: bBoard for UNB Rev 4.SCHLIB
R1 = Res Semi: RES 374K OHM 1% 1/10W 0603; Manufacturer: Stackpole Electronics; Manufacturer Part Number: RMCF0603FT374K; Max Power Dissipation: 100mW; Resistance: 374kR; Temperature Coefficient: 100ppm/°C; Case Code (Imperial): 0603; Case Code (Metric): 1608; Case/Package: 0603; Category: Chip SMD Resistors; Component Kind: Standard; ComponentLink1Description: http://www.rohm.com/web/global/datasheet/ESR01MZPF/esr-e; ComponentLink1URL: http://www.rohm.com/web/global/datasheet/ESR01MZPF/esr-e; ComponentLink2Description: http://components.arrow.com/part/dataview/50501841S601819N1174?src-supplier=Arrow+Electronics; ComponentLink2URL: http://components.arrow.com/part/dataview/50501841S601819N1174?src-supplier=Arrow+Electronics; ComponentLink3Description: https://www.seielect.com/Catalog/SEI-RMCF_RMCP.pdf?src-supplier=Digi-Key; ComponentLink3URL: https://www.seielect.com/Catalog/SEI-RMCF_RMCP.pdf?src-supplier=Digi-Key; ComponentLink4Description: https://www.seielect.com/catalog/SEI-RMCF_RMCP.pdf?src-supplier=Avnet; ComponentLink4URL: https://www.seielect.com/catalog/SEI-RMCF_RMCP.pdf?src-supplier=Avnet; Composition: Thick Film; Contact Plating: Tin,Matte; Depth: 0.8mm; Features: Automotive AEC-Q200; Height: 0.022inch; Lead Free: Contains Lead; Length: 0.061inch; Max Operating Temperature: 155°C; Military Standard: Not; Min Operating Temperature: -55°C; Mount: Surface Mount; Number of Terminations: 2; Package Quantity: 5000; Packaging: Tape and Reel; Radiation Hardening: No; RoHS: Yes; Signal Integrity: Res Semi; Simulation: RES; Stock 1: 11056; Supplier 1: Digi-Key; Supplier Part Number 1: RMCF0603FT374KCT-ND; Tolerance: 1%; Value: 374k; Width: 0.031inch; Library Name: BrillianBit.SCHLIB
R2 R3 R5 = Res Semi: RES 100K OHM 1% 1/10W 0603; Manufacturer: Stackpole Electronics; Manufacturer Part Number: RMCF0603FT100K; Max Power Dissipation: 100mW; Resistance: 100kR; Temperature Coefficient: 100ppm/°C; Working Voltage: 75V; Case Code (Imperial): 0603; Case Code (Metric): 1608; Case/Package: 0603; Category: Chip SMD Resistors; Component Kind: Standard; ComponentLink1Description: http://www.rohm.com/web/global/datasheet/ESR01MZPF/esr-e; ComponentLink1URL: http://www.rohm.com/web/global/datasheet/ESR01MZPF/esr-e; ComponentLink2Description: https://datasheet.ciiva.com/15193/getdatasheetpartid-362597-15193437.pdf?src-supplier=Verical; ComponentLink2URL: https://datasheet.ciiva.com/15193/getdatasheetpartid-362597-15193437.pdf?src-supplier=Verical; Composition: Thick Film; Contact Plating: Tin,Matte; Depth: 0.8mm; Features: Automotive AEC-Q200; Height: 0.022inch; Lead Free: Lead Free; Length: 1.55mm; Max Operating Temperature: 155°C; Military Standard: Not; Min Operating Temperature: -55°C; Mount: Surface Mount; Number of Terminations: 2; Packaging: Tape and Reel; Power Rating: 100mW; Radiation Hardening: No; RoHS: Yes; Signal Integrity: Res Semi; Simulation: RES; Stock 1: 2666095; Supplier 1: Digi-Key; Supplier Part Number 1: RMCF0603FT100KDKR-ND; Tolerance: 1%; Value: 100k; Width: 0.031inch; Library Name: BrillianBit.SCHLIB
R4 = Res Semi: RES SMD 619K OHM 1% 1/10W 0603; Manufacturer: Stackpole Electronics; Manufacturer Part Number: RMCF0603FT619K; Max Power Dissipation: 100mW; Resistance: 619kR; Temperature Coefficient: 100ppm/°C; Case Code (Imperial): 0603; Case Code (Metric): 1608; Case/Package: 0603; Category: Chip SMD Resistors; Component Kind: Standard; ComponentLink1Description: http://www.rohm.com/web/global/datasheet/ESR01MZPF/esr-e; ComponentLink1URL: http://www.rohm.com/web/global/datasheet/ESR01MZPF/esr-e; ComponentLink2Description: https://www.seielect.com/catalog/SEI-RMCF_RMCP.pdf?src-supplier=Avnet; ComponentLink2URL: https://www.seielect.com/catalog/SEI-RMCF_RMCP.pdf?src-supplier=Avnet; ComponentLink3Description: http://www.seielect.com/Catalog/SEI-RMC.pdf?src-supplier=Future+Electronics; ComponentLink3URL: http://www.seielect.com/Catalog/SEI-RMC.pdf?src-supplier=Future+Electronics; ComponentLink4Description: https://www.seielect.com/catalog/SEI-Packaging.pdf?src-supplier=Digi-Key; ComponentLink4URL: https://www.seielect.com/catalog/SEI-Packaging.pdf?src-supplier=Digi-Key; ComponentLink5Description: https://www.seielect.com/Catalog/SEI-RMCF_RMCP.pdf?src-supplier=Digi-Key; ComponentLink5URL: https://www.seielect.com/Catalog/SEI-RMCF_RMCP.pdf?src-supplier=Digi-Key; Composition: Thick Film; Contact Plating: Tin,Matte; Features: Automotive AEC-Q200; Height: 0.022inch; Lead Free: Lead Free; Length: 0.061inch; Max Operating Temperature: 155°C; Min Operating Temperature: -55°C; Mount: Surface Mount; Number of Terminations: 2; Packaging: Tape and Reel; Power Rating: 100mW; Radiation Hardening: No; RoHS: Yes; Signal Integrity: Res Semi; Simulation: RES; Stock 1: 7746; Supplier 1: Digi-Key; Supplier Part Number 1: RMCF0603FT619KCT-ND; Tolerance: 1%; Value: 619k; Width: 0.031inch; Library Name: BrillianBit.SCHLIB
R12 = Res Semi: Res Thick Film 0603 1K Ohm 1% 0.1W(1/10W) ±100ppm/C Pad SMD Automotive T/R; Manufacturer: Stackpole Electronics; Manufacturer Part Number: RMCF0603FT1K00; Max Power Dissipation: 100mW; Resistance: 1kR; Temperature Coefficient: 100ppm/°C; Case Code (Imperial): 0603; Case Code (Metric): 1608; Case/Package: 0603; Category: Chip SMD Resistors; Component Kind: Standard; ComponentLink1Description: http://www.rohm.com/web/global/datasheet/ESR01MZPF/esr-e; ComponentLink1URL: http://www.rohm.com/web/global/datasheet/ESR01MZPF/esr-e; ComponentLink2Description: https://www.seielect.com/catalog/SEI-Packaging.pdf?src-supplier=Avnet; ComponentLink2URL: https://www.seielect.com/catalog/SEI-Packaging.pdf?src-supplier=Avnet; ComponentLink3Description: https://www.seielect.com/catalog/sei-rmcf_rmcp.pdf?src-supplier=Digi-Key; ComponentLink3URL: https://www.seielect.com/catalog/sei-rmcf_rmcp.pdf?src-supplier=Digi-Key; ComponentLink4Description: https://www.seielect.com/catalog/SEI-RMCF_RMCP.pdf?src-supplier=Avnet; ComponentLink4URL: https://www.seielect.com/catalog/SEI-RMCF_RMCP.pdf?src-supplier=Avnet; ComponentLink5Description: http://www.seielect.com/Catalog/SEI-rmc.pdf?src-supplier=Future+Electronics; ComponentLink5URL: http://www.seielect.com/Catalog/SEI-rmc.pdf?src-supplier=Future+Electronics; ComponentLink6Description: https://www.seielect.com/catalog/SEI-RMCF_RMCP.pdf?src-supplier=Avnet+Asia; ComponentLink6URL: https://www.seielect.com/catalog/SEI-RMCF_RMCP.pdf?src-supplier=Avnet+Asia; ComponentLink7Description: https://www.seielect.com/catalog/SEI-Packaging.pdf?src-supplier=Verical; ComponentLink7URL: https://www.seielect.com/catalog/SEI-Packaging.pdf?src-supplier=Verical; ComponentLink8Description: https://www.seielect.com/catalog/SEI-Packaging.pdf?src-supplier=Arrow+Electronics; ComponentLink8URL: https://www.seielect.com/catalog/SEI-Packaging.pdf?src-supplier=Arrow+Electronics; ComponentLink9Description: https://www.seielect.com/catalog/SEI-Packaging.pdf?src-supplier=Quest; ComponentLink9URL: https://www.seielect.com/catalog/SEI-Packaging.pdf?src-supplier=Quest; Composition: Thick Film; Contact Plating: Tin,Matte; Depth: 0.8mm; Features: Automotive AEC-Q200; Height: 0.022inch; Lead Free: Lead Free; Length: 1.55mm; Max Operating Temperature: 155°C; Military Standard: Not; Min Operating Temperature: -55°C; Mount: Surface Mount; Number of Terminations: 2; Packaging: Tape and Reel; Power Rating: 100mW; Radiation Hardening: No; RoHS: Yes; Signal Integrity: Res Semi; Simulation: RES; Stock 1: 4210775; Supplier 1: Digi-Key; Supplier Part Number 1: RMCF0603FT1K00CT-ND; Tolerance: 1%; Value: 1k; Width: 0.031inch; Library Name: BrillianBit.SCHLIB
R14 R15 R17 = RES MC00625W0402110K: Fixed Resistor, Metal Glaze/thick Film, 0.0625W, 10000ohm, 50V, 1% +/-Tol, 100ppm/Cel, Surface Mount, 0402; Manufacturer: Multicomp; Rated Power Dissipation (P): 63mW; Rated Temperature: 70°C; Temperature Coefficient: 100ppm/°C; Category: Chip SMD Resistors; Component Kind: Standard; ComponentLink1Description: https://datasheet.ciiva.com:443/pdfs/VipMasterIC/IC/SCMP/SCMPS00098/SCMPS00098-1.pdf?src-supplier=IHS+Markit; ComponentLink1URL: https://datasheet.ciiva.com:443/pdfs/VipMasterIC/IC/SCMP/SCMPS00098/SCMPS00098-1.pdf?src-supplier=IHS+Markit; ComponentLink2Description: https://datasheet.ciiva.com/28638/1729045-28638923.pdf?src-supplier=Element14; ComponentLink2URL: https://datasheet.ciiva.com/28638/1729045-28638923.pdf?src-supplier=Element14; Manufacturer Part Number: MC00625W0402110K; Max Operating Temperature: 155°C; Min Operating Temperature: -55°C; Mount: Surface Mount; Number of Pins: 2; Package Shape: Rectangular; Packaging: Tape and Reel; Pricing 1: 10000=0.006, 20000=0.005, 50000=0.004, 100000=0.002 (USD); Reach SVHC Compliant: Yes; Resistor Type: FIXED RESISTOR; RoHS: Yes; RoHS Compliant: Yes; Size Code: 0402; Stock 1: 700000; Supplier 1: Newark; Supplier Part Number 1: 07W4403; Technology: METAL GLAZE/THICK FILM; Termination: Wraparound; Tolerance: 1%; Working Voltage: 50V; Library Name: bBoard for UNB Rev 3.SCHLIB
R16 = RES RC0402JR-0747KL: Fixed Resistor, Metal Glaze/thick Film, 0.0625W, 47000ohm, 50V, 5% +/-Tol, 100ppm/Cel, Surface Mount, 0402; Manufacturer: Yageo; Additional Feature: LASER TRIMMABLE; Package Height: 0.35mm; Package Length: 1mm; Package Width: 0.5mm; Rated Power Dissipation (P): 62.5mW; Rated Temperature: 70°C; Temperature Coefficient: 100ppm/°C; Category: Chip SMD Resistors; Component Kind: Standard; ComponentLink1Description: https://datasheet.ciiva.com:443/pdfs/VipMasterIC/IC/YAGO/YAGO-S-A0008145938/YAGO-S-A0008145938-1.pdf?src-supplier=IHS+Markit; ComponentLink1URL: https://datasheet.ciiva.com:443/pdfs/VipMasterIC/IC/YAGO/YAGO-S-A0008145938/YAGO-S-A0008145938-1.pdf?src-supplier=IHS+Markit; ComponentLink2Description: https://datasheet.ciiva.com/2452/pyu-r-int-thick-7-2452641.pdf?src-supplier=Digikey; ComponentLink2URL: https://datasheet.ciiva.com/2452/pyu-r-int-thick-7-2452641.pdf?src-supplier=Digikey; ComponentLink3Description: https://datasheet.ciiva.com/2452/pyu-r-int-thick-7-2452642.pdf?src-supplier=Digikey; ComponentLink3URL: https://datasheet.ciiva.com/2452/pyu-r-int-thick-7-2452642.pdf?src-supplier=Digikey; ComponentLink4Description: https://datasheet.ciiva.com/2452/pyu-r-int-thick-7-2452643.pdf?src-supplier=Digikey; ComponentLink4URL: https://datasheet.ciiva.com/2452/pyu-r-int-thick-7-2452643.pdf?src-supplier=Digikey; ComponentLink5Description: https://datasheet.ciiva.com/12667/pyu-rc0402-51-rohs-l-6-r-12667910.pdf?src-supplier=Mouser; ComponentLink5URL: https://datasheet.ciiva.com/12667/pyu-rc0402-51-rohs-l-6-r-12667910.pdf?src-supplier=Mouser; ComponentLink6Description: https://datasheet.ciiva.com/46394/1018594-46394970.pdf?src-supplier=Element14; ComponentLink6URL: https://datasheet.ciiva.com/46394/1018594-46394970.pdf?src-supplier=Element14; ComponentLink7Description: https://datasheet.ciiva.com/7850/1018594-7850218.pdf?src-supplier=Element14; ComponentLink7URL: https://datasheet.ciiva.com/7850/1018594-7850218.pdf?src-supplier=Element14; ComponentLink8Description: https://datasheet.ciiva.com/7850/1018594-7850219.pdf?src-supplier=Element14; ComponentLink8URL: https://datasheet.ciiva.com/7850/1018594-7850219.pdf?src-supplier=Element14; ComponentLink9Description: https://datasheet.ciiva.com/16740/pyu-rc0402-51-rohs-l-6-r-16740971.pdf?src-supplier=Future+Electronics; ComponentLink9URL: https://datasheet.ciiva.com/16740/pyu-rc0402-51-rohs-l-6-r-16740971.pdf?src-supplier=Future+Electronics; Construction: Chip; JESD-609 Code: e3; Manufacturer Part Number: RC0402JR-0747KL; Max Operating Temperature: 155°C; Min Operating Temperature: -55°C; Mount: Surface Mount; Number of Pins: 2; Package Shape: Rectangular; Package Style: SMTMeter; Packaging: Tape and Reel; Pricing 1: 1=0.1, 10=0.018, 50=0.0102, 100=0.0083, 500=0.00528, 1000=0.00444, 5000=0.00307 (USD); Reach SVHC Compliant: Yes; Resistor Type: FIXED RESISTOR; RoHS: Yes; RoHS Compliant: Yes; Size Code: 0402; Stock 1: 358338; Supplier 1: Digikey; Supplier Part Number 1: 311-47KJRCT-ND; Technology: METAL GLAZE/THICK FILM; Terminal Finish: Nickel; Termination: Wraparound; Tolerance: 5%; Working Voltage: 50V; Library Name: bBoard for UNB Rev 3.SCHLIB
R49 = RES MCWR04X1003FTL: Fixed Resistor, Metal Glaze/thick Film, 0.0625W, 100000ohm, 50V, 1% +/-Tol, 100ppm/Cel, Surface Mount, 0402; Manufacturer: Multicomp; Rated Power Dissipation (P): 62.5mW; Rated Temperature: 70°C; Temperature Coefficient: 100ppm/°C; Component Kind: Standard; ComponentLink1Description: https://datasheet.ciiva.com:443/pdfs/VipMasterIC/IC/SCMP/SCMP-S-A0002061452/SCMP-S-A0002061452-1.pdf?src-supplier=IHS+Markit; ComponentLink1URL: https://datasheet.ciiva.com:443/pdfs/VipMasterIC/IC/SCMP/SCMP-S-A0002061452/SCMP-S-A0002061452-1.pdf?src-supplier=IHS+Markit; ComponentLink2Description: https://datasheet.ciiva.com/46386/1849611-46386524.pdf?src-supplier=Element14; ComponentLink2URL: https://datasheet.ciiva.com/46386/1849611-46386524.pdf?src-supplier=Element14; JESD-609 Code: e3; Manufacturer Part Number: MCWR04X1003FTL; Max Operating Temperature: 155°C; Min Operating Temperature: -55°C; Mount: Surface Mount; Number of Pins: 2; Package Shape: Rectangular; Packaging: Tape and Reel; Pricing 1: 1=0.028, 10=0.024, 100=0.02, 1000=0.014 (USD); Resistor Type: FIXED RESISTOR; RoHS: Yes; RoHS Compliant: Yes; Size Code: 0402; Stock 1: 29891; Supplier 1: Newark; Supplier Part Number 1: 94X1105; Technology: METAL GLAZE/THICK FILM; Terminal Finish: Tin; Termination: Wraparound; Tolerance: 1%; Working Voltage: 50V; Library Name: bBoard for UNB Rev 4.SCHLIB
R57 = RES RC0402JR-0733KL: Fixed Resistor, Metal Glaze/thick Film, 0.0625W, 33000ohm, 50V, 5% +/-Tol, 100ppm/Cel, Surface Mount, 0402; Manufacturer: Yageo; Additional Feature: LASER TRIMMABLE; Package Height: 0.35mm; Package Length: 1mm; Package Width: 0.5mm; Rated Power Dissipation (P): 62.5mW; Rated Temperature: 70°C; Temperature Coefficient: 100ppm/°C; Category: Chip SMD Resistors; Component Kind: Standard; ComponentLink1Description: https://datasheet.ciiva.com:443/pdfs/VipMasterIC/IC/YAGO/YAGO-S-A0008145938/YAGO-S-A0008145938-1.pdf?src-supplier=IHS+Markit; ComponentLink1URL: https://datasheet.ciiva.com:443/pdfs/VipMasterIC/IC/YAGO/YAGO-S-A0008145938/YAGO-S-A0008145938-1.pdf?src-supplier=IHS+Markit; ComponentLink2Description: https://datasheet.ciiva.com/7850/1018594-7850198.pdf?src-supplier=Element14; ComponentLink2URL: https://datasheet.ciiva.com/7850/1018594-7850198.pdf?src-supplier=Element14; ComponentLink3Description: https://datasheet.ciiva.com/7850/1018594-7850199.pdf?src-supplier=Element14; ComponentLink3URL: https://datasheet.ciiva.com/7850/1018594-7850199.pdf?src-supplier=Element14; ComponentLink4Description: https://datasheet.ciiva.com/7983/1018594-7983578.pdf?src-supplier=Element14; ComponentLink4URL: https://datasheet.ciiva.com/7983/1018594-7983578.pdf?src-supplier=Element14; ComponentLink5Description: https://datasheet.ciiva.com/5558/pyu-rc0402-51-rohs-l-6-r-5558154.pdf?src-supplier=Mouser; ComponentLink5URL: https://datasheet.ciiva.com/5558/pyu-rc0402-51-rohs-l-6-r-5558154.pdf?src-supplier=Mouser; ComponentLink6Description: https://datasheet.ciiva.com/16740/pyu-rc0402-51-rohs-l-6-r-16740743.pdf?src-supplier=Future+Electronics; ComponentLink6URL: https://datasheet.ciiva.com/16740/pyu-rc0402-51-rohs-l-6-r-16740743.pdf?src-supplier=Future+Electronics; Construction: Chip; JESD-609 Code: e3; Manufacturer Part Number: RC0402JR-0733KL; Max Operating Temperature: 155°C; Min Operating Temperature: -55°C; Mount: Surface Mount; Number of Pins: 2; Package Shape: Rectangular; Package Style: SMTMeter; Packaging: Tape and Reel; Pricing 1: 1=0.1, 10=0.018, 50=0.0102, 100=0.0083, 500=0.00528, 1000=0.00444, 5000=0.00307 (USD); Reach SVHC Compliant: Yes; Resistor Type: FIXED RESISTOR; RoHS: Yes; RoHS Compliant: Yes; Size Code: 0402; Stock 1: 166093; Supplier 1: Digikey; Supplier Part Number 1: 311-33KJRCT-ND; Technology: METAL GLAZE/THICK FILM; Terminal Finish: Nickel; Termination: Wraparound; Tolerance: 5%; Working Voltage: 50V; Library Name: bBoard for UNB Rev 4.SCHLIB
S1 = SW-SPDT: SWITCH SLIDE SPDT 300MA 30V; Manufacturer: E-Switch; Manufacturer Part Number: EG1271; Actuator Length: 2mm; Actuator Type: Standard; Contact Style: Non-Shorting; Electrical Life: 10000Cycles; Illumination Type: Non-Illuminated; Operating Force: 200gf; Switch Function: On-On; Throw Configuration: SPDT,DPDT; Category: Slide Switches; Component Kind: Standard; ComponentLink1Description: http://spec_sheets.e-switch.com/specs/P040047.pdf; ComponentLink1URL: http://spec_sheets.e-switch.com/specs/P040047.pdf; ComponentLink2Description: https://datasheet.ciiva.com/16174/p040046-16174279.pdf?src-supplier=Onlinecomponents.com; ComponentLink2URL: https://datasheet.ciiva.com/16174/p040046-16174279.pdf?src-supplier=Onlinecomponents.com; ComponentLink3Description: https://datasheet.ciiva.com/6967/p040046e-6967523.pdf?src-supplier=Newark; ComponentLink3URL: https://datasheet.ciiva.com/6967/p040046e-6967523.pdf?src-supplier=Newark; ComponentLink4Description: https://datasheet.ciiva.com/2889/p040046-2889380.pdf?src-supplier=Digi-Key; ComponentLink4URL: https://datasheet.ciiva.com/2889/p040046-2889380.pdf?src-supplier=Digi-Key; ComponentLink5Description: https://datasheet.ciiva.com/16297/eg-16297513.pdf?src-supplier=Future+Electronics; ComponentLink5URL: https://datasheet.ciiva.com/16297/eg-16297513.pdf?src-supplier=Future+Electronics; Contact Current Rating: 300mA; Contact Material: Copper Alloy,Copper,Silver; Contact Plating: Silver; Current Rating: 300mA; Lead Free: Lead Free; Max Operating Temperature: 70°C; Max Voltage Rating (DC): 30V; Min Operating Temperature: -25°C; Mount: Through Hole; Orientation: Straight; Package Quantity: 1000; Packaging: Bulk; REACH SVHC: No SVHC; RoHS: Yes; Stock 1: 963; Supplier 1: Digi-Key; Supplier Part Number 1: EG1918-ND; Switch Travel: 2mm; Termination: PC Pin; Voltage Rating (AC): 30V; Voltage Rating (DC): 30V; Library Name: BrilliantLabs_SchLib.SchLib
SW1 = PTS636SK25FSMTRLFS: Keypad Switch, 1 Switches, SPST, Momentary-tactile, 0.05A, 12VDC, 2.94N, Solder Terminal, Surface Mount-straight; Manufacturer: ITT C&K; Component Kind: Standard; ComponentLink1Description: https://dznh3ojzb2azq.cloudfront.net/products/Tactile/PTS636/documents/datasheet.pdf?src-supplier=Digikey; ComponentLink1URL: https://dznh3ojzb2azq.cloudfront.net/products/Tactile/PTS636/documents/datasheet.pdf?src-supplier=Digikey; ComponentLink2Description: https://datasheet.octopart.com/PTS636SK25FSMTRLFS-C%26K-Components-datasheet-136360845.pdf?src-supplier=Sager+Electronics; ComponentLink2URL: https://datasheet.octopart.com/PTS636SK25FSMTRLFS-C%26K-Components-datasheet-136360845.pdf?src-supplier=Sager+Electronics; ComponentLink3Description: https://datasheet.octopart.com/PTS636-SK25F-SMTR-LFS-C%26K-Components-datasheet-166147775.pdf?src-supplier=TME; ComponentLink3URL: https://datasheet.octopart.com/PTS636-SK25F-SMTR-LFS-C%26K-Components-datasheet-166147775.pdf?src-supplier=TME; Manufacturer Part Number: PTS636SK25FSMTRLFS; Pricing 1: 1=0.24, 10=0.231, 25=0.2196, 100=0.1987, 250=0.18112, 500=0.16836, 1000=0.14362 (USD); RoHS: No; RoHS Compliant: Yes; Stock 1: 42261; Supplier 1: Digikey; Supplier Part Number 1: CKN12330-1-ND; Library Name: bBoard for UNB Rev 4.SCHLIB
U1 U2 = TPS621351: IC REG BUCK ADJ 3.5A 11VQFN; Manufacturer: Texas Instruments; Manufacturer Part Number: TPS621351RGXT; Max Input Voltage: 17V; Max Output Voltage: 12V; Min Input Voltage: 3V; Min Output Voltage: 800mV; Number of Outputs: 1; Output Configuration: Positive; Output Type: Adjustable; Quiescent Current: 18uA; Switching Frequency: 2.5MHz; Synchronous Rectifier: Yes; Case/Package: VQFN; Category: Voltage Regulators - Switching; Component Kind: Standard; ComponentLink1Description: http://www.ti.com/lit/ds/symlink/tps62135.pdf?src-supplier=Digi-Key; ComponentLink1URL: http://www.ti.com/lit/ds/symlink/tps62135.pdf?src-supplier=Digi-Key; Lead Free: Lead Free; Max Operating Temperature: 125°C; Min Operating Temperature: -40°C; Mount: Surface Mount; Number of Pins: 11; Output Current: 3.5A; Packaging: Tape and Reel; RoHS: Yes; Stock 1: 84; Supplier 1: Digi-Key; Supplier Part Number 1: 296-44877-1-ND; Library Name: BrilliantLabs_SchLib.SchLib
